# Altium schematic sheet Filter.SchDoc
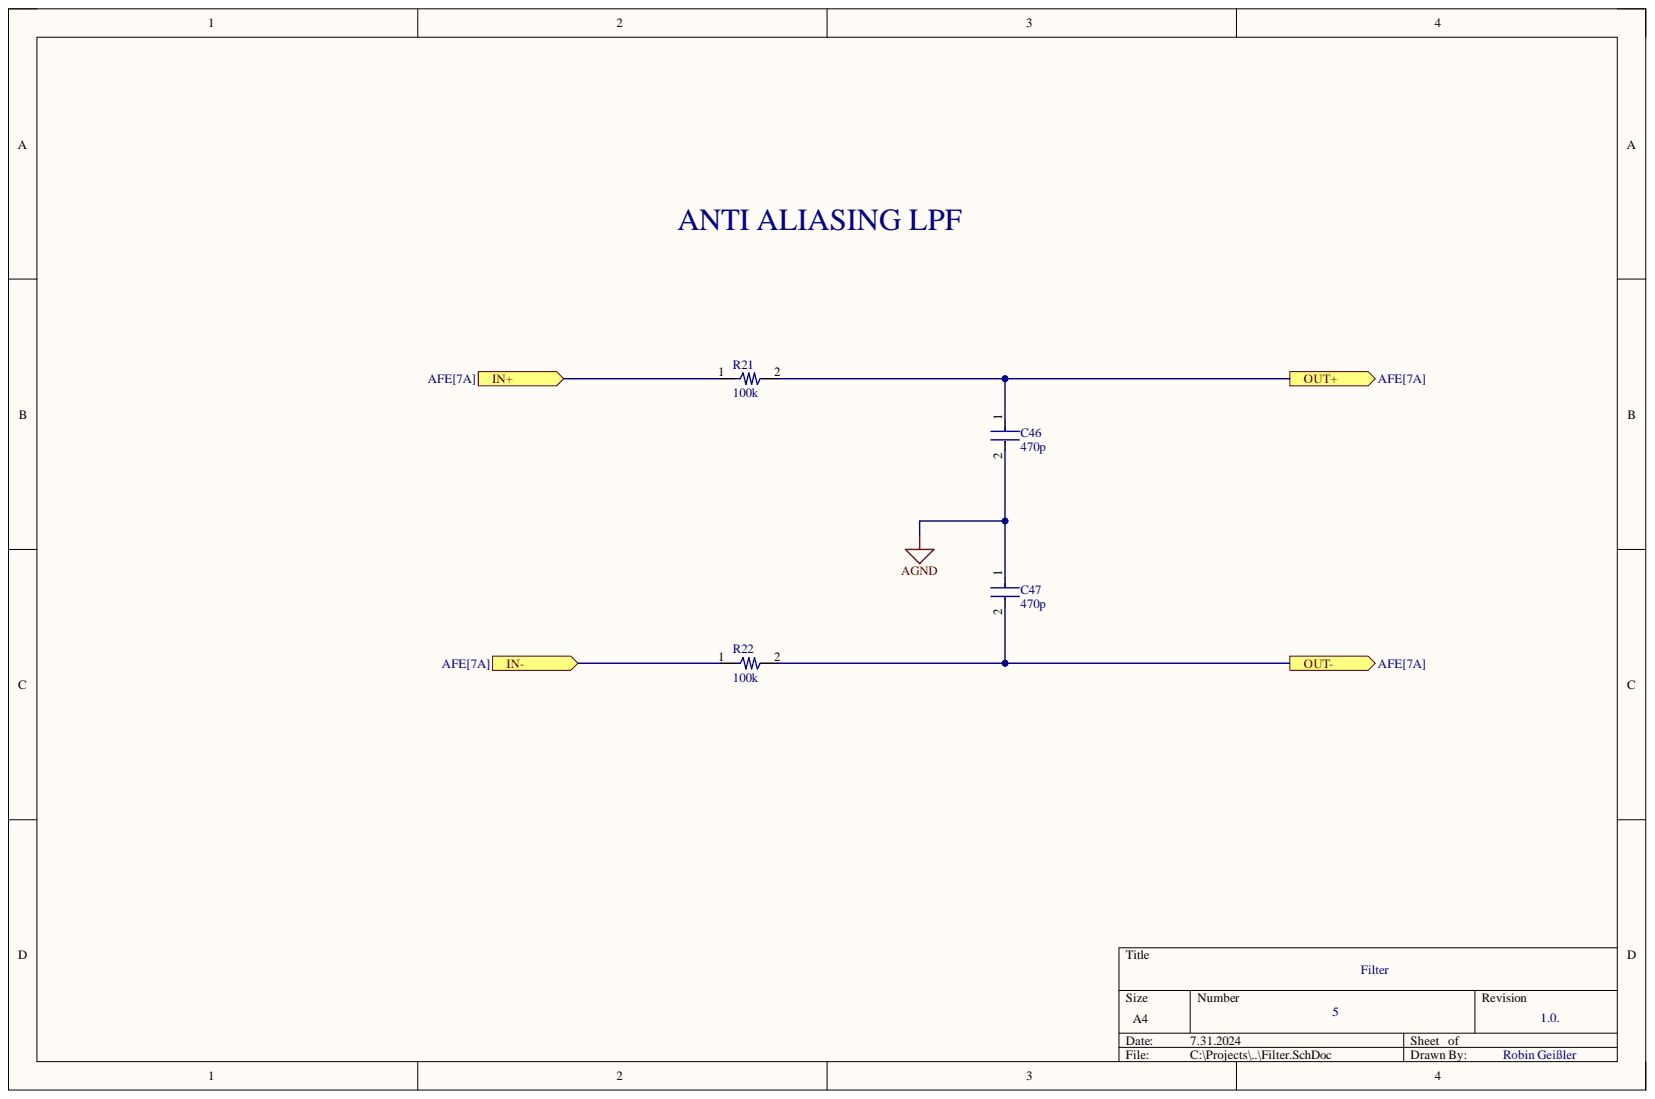
[diagram p0: Filter.SchDoc]

## Parts
C46  470p  [FP-C0603C-CF-MFG]
C47  470p  [FP-C0603C-CF-MFG]
R21  100k  [R-1608 [0603]-M]
R22  100k  [R-1608 [0603]-M]

## Ports
IN+, IN-, OUT+, OUT-

## Nets
AGND: C46.2 C47.1
NetC46_1: C46.1 R21.2
NetC47_2: C47.2 R22.2
NetR21_1: R21.1
NetR22_1: R22.1

## Library data of the parts
C46 C47 = CMP-1035-01678-2: C0603 470 pF C0G 30ppm/°C 1.00% 100 V; Manufacturer: KEMET; Manufacturer Part Number: C0603C471F1GACTU; Automotive Grade: Grade 1; Capacitance: 470 pF; Material: Ceramic; Tolerance: 0.01; Value: 470 pF; Voltage Rating: 100 V; Automotive: Yes; Category: Cap; Component Kind: Standard; ComponentLink2Description: Datasheet; ComponentLink2URL: https://api.kemet.com/component-edge/download/datasheet/C0603C100J5GACAUTO.pdf; Device Class L1: Passive Components; Device Class L2: Capacitors; Device Class L3: Ceramic Capacitors; Height: 0.87mm; Lead Free: Yes; Library Name: Altium Content Vault; Mouser Description: Multilayer Ceramic Capacitors MLCC - SMD/SMT 100volts 470pF 1% C0G; Octopart: https://octopart.com/c0603c471f1gactu-kemet-26647159; Package: C0603C-CF; RoHS: TRUE; Temperature: -55°C to +125°C; Temperature Characteristic: C0G; Temperature Coefficient: 30ppm/°C; Temperature Range High: +125°C; Temperature Range Low: -55°C
R21 R22 = Precision_Resistor: Expensive: P2TC0603D1003LNTA - 0.01% Cheap: TNPU0603100KAYEN00 - 0.05%; Component Kind: Standard; Supplier 1: Rs; Supplier 2: Arrow Electronics; Supplier Part Number 1: 2182743P; Supplier Part Number 2: TNPU0603100KAYEN00; Library Name: EMG_Sensor.SchLib
